# Altium schematic sheet 02_OCDS.SchDoc
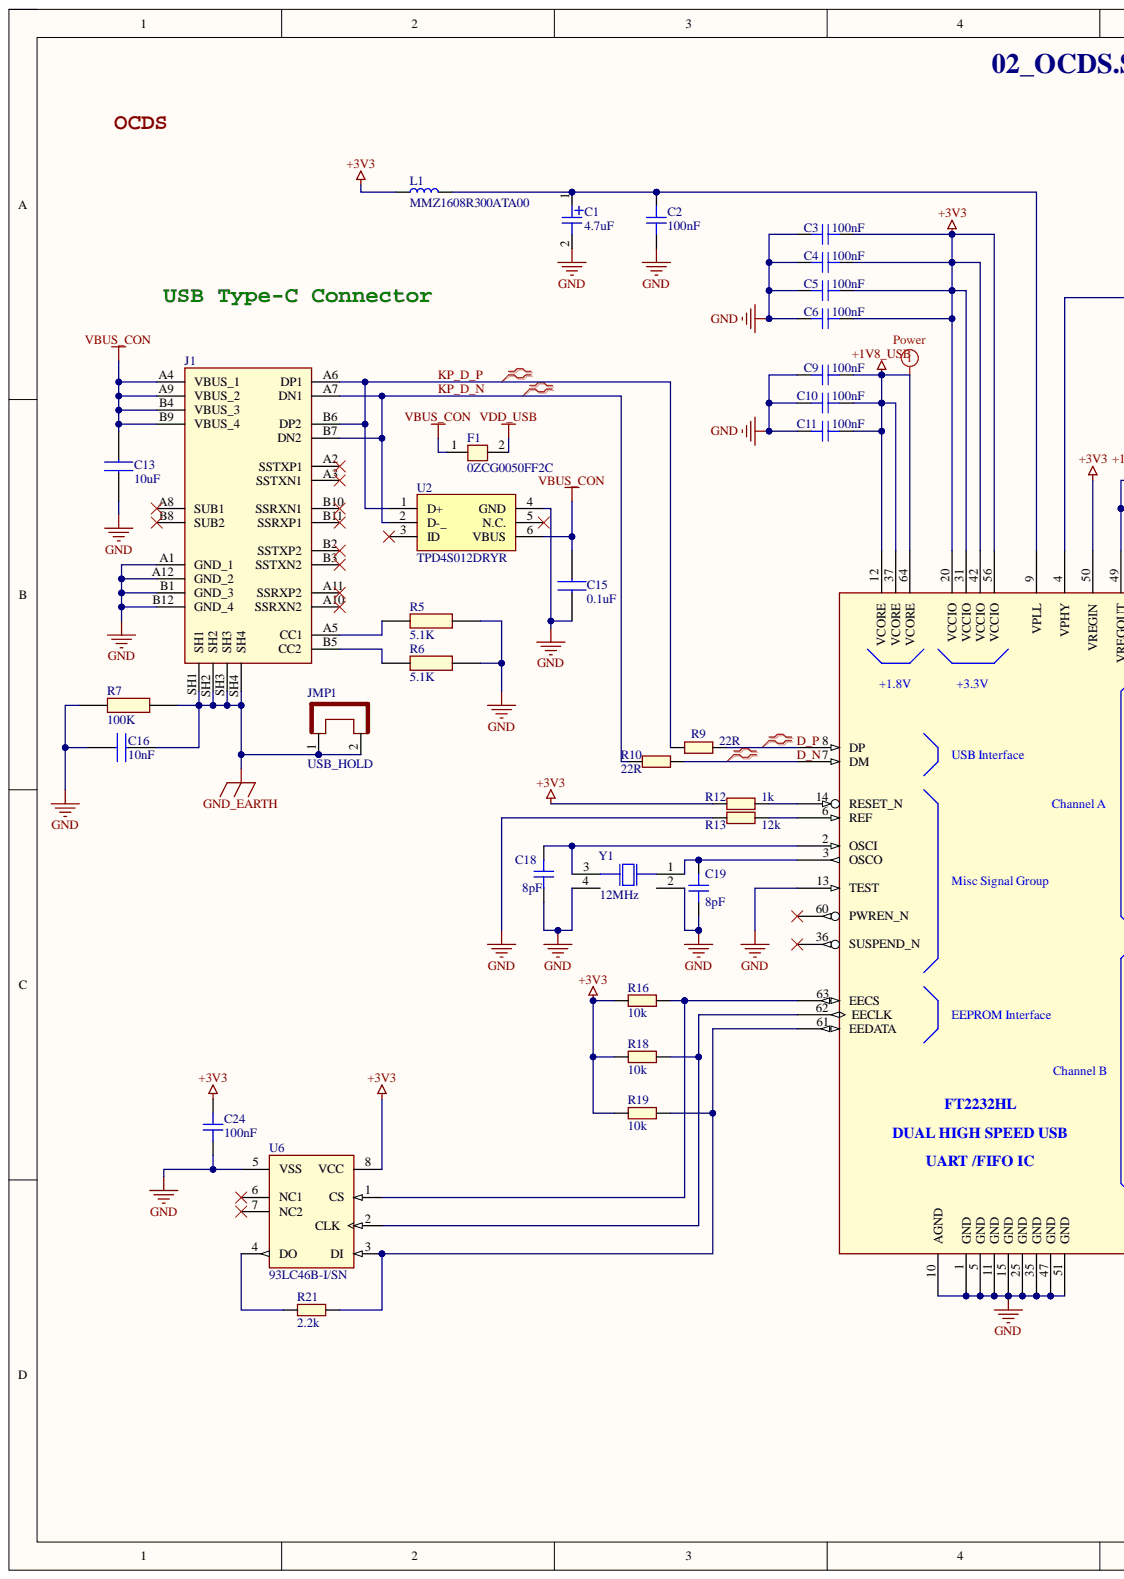
[diagram p0: 02_OCDS.SchDoc, left]
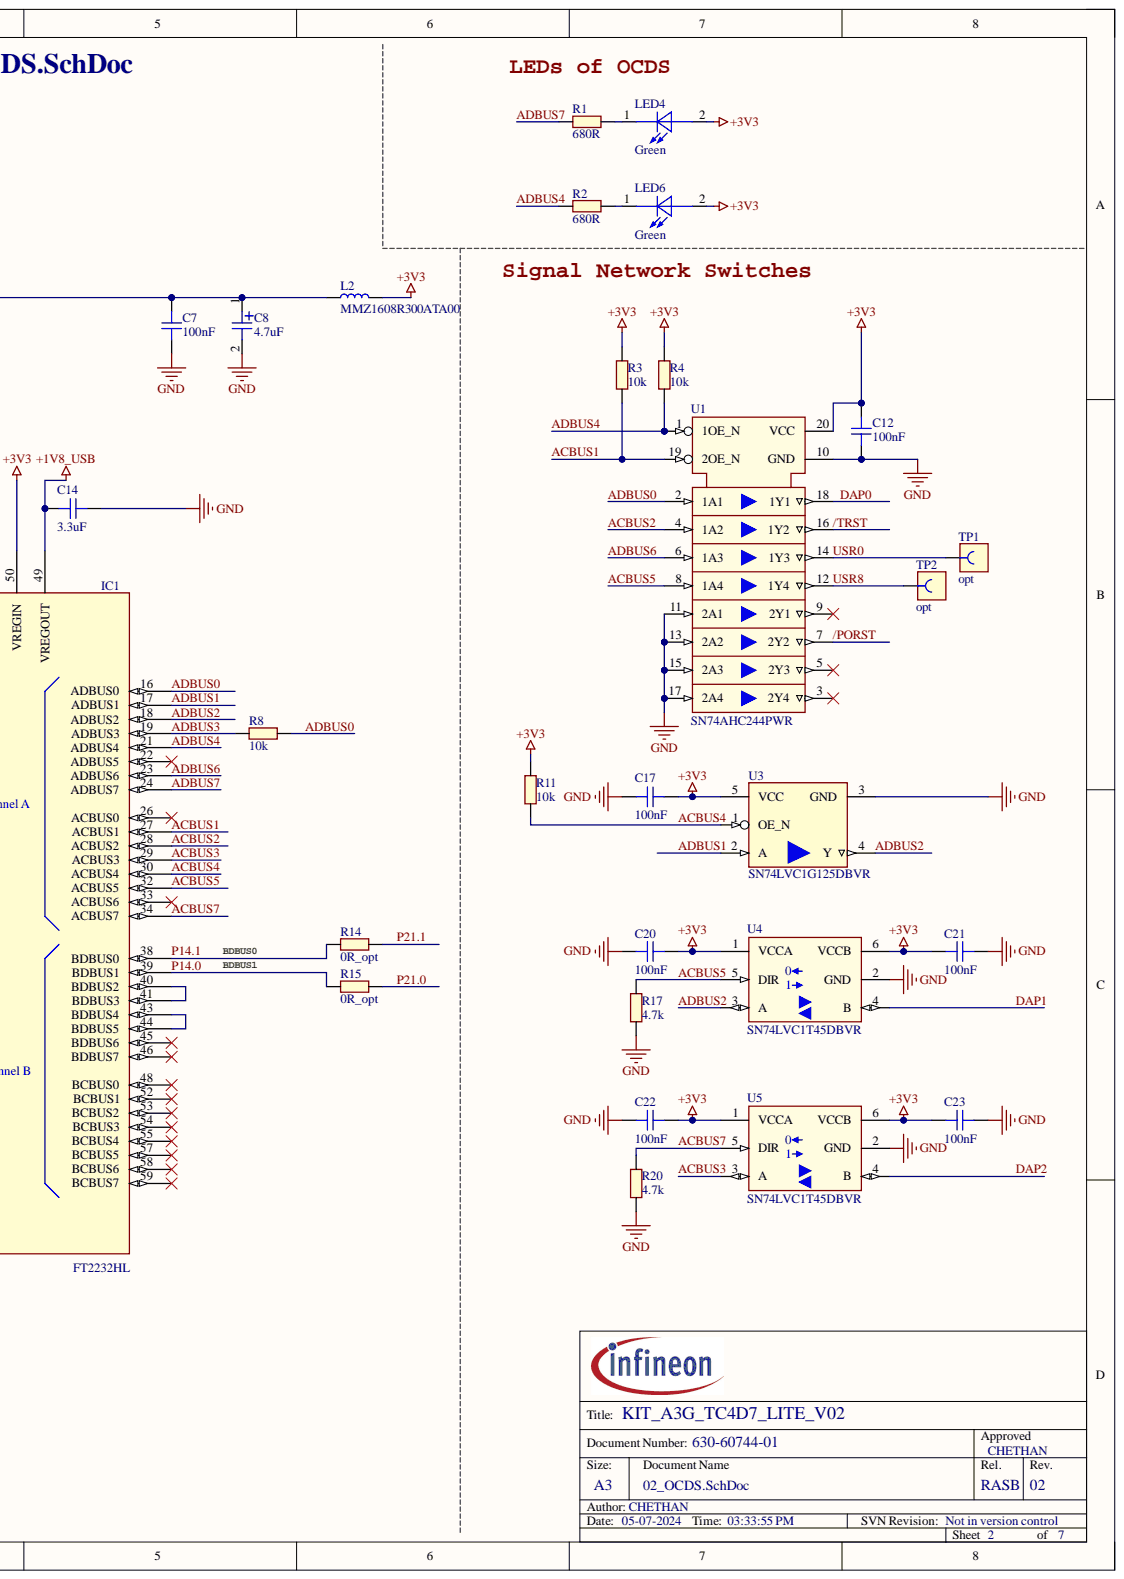
[diagram p1: 02_OCDS.SchDoc, right]

## Parts
C1  4.7uF  [CAPMP3216X180N]
C2  100nF  [CAPC1005X55N-1]
C3  100nF  [CAPC1005X55N-1]
C4  100nF  [CAPC1005X55N-1]
C5  100nF  [CAPC1005X55N-1]
C6  100nF  [CAPC1005X55N-1]
C7  100nF  [CAPC1005X55N-1]
C8  4.7uF  [CAPMP3216X180N]
C9  100nF  [CAPC1005X55N-1]
C10  100nF  [CAPC1005X55N-1]
C11  100nF  [CAPC1005X55N-1]
C12  100nF  [CAPC1005X55N-1]
C13  10uF  [CAPC2012X145N]
C14  3.3uF  [CAPC2013X95N]
C15  0.1uF  [CAPC1005X55N]
C16  10nF  [CAPC1005X55N-1]
C17  100nF  [CAPC1005X55N-1]
C18  8pF  [CAPC1005X55N-0]
C19  8pF  [CAPC1005X55N-0]
C20  100nF  [CAPC1005X55N-1]
C21  100nF  [CAPC1005X55N-1]
C22  100nF  [CAPC1005X55N-1]
C23  100nF  [CAPC1005X55N-1]
C24  100nF  [CAPC1005X55N-1]
F1  0ZCG0050FF2C  [0ZCG0050FF2C]
IC1  FT2232HL  [QFP50P1200X1200X160-64N]
J1  DX07S024XJ1R1100  [DX07S024XJ1R1100]  (value not drawn)
JMP1  USB_HOLD
L1  MMZ1608R300ATA00  [INDC1608X95N_MMZ1608]
L2  MMZ1608R300ATA00  [INDC1608X95N_MMZ1608]
LED4  Green  [LED-SMD-LG L29K-XXXX-24]
LED6  Green  [LED-SMD-LG L29K-XXXX-24]
R1  680R  [RESC1608X55N-1]
R2  680R  [RESC1608X55N-1]
R3  10k  [RESC1005X40N]
R4  10k  [RESC1005X40N]
R5  5.1K  [RESC1005X40N]
R6  5.1K  [RESC1005X40N]
R7  100K  [RESC1005X40N_1]
R8  10k  [RESC1005X40N]
R9  22R  [RESC1005X40N]
R10  22R  [RESC1005X40N]
R11  10k  [RESC1005X40N]
R12  1k  [RESC1005X40N-1]
R13  12k  [RESC1005X40N]
R14  0R_opt  [RESC1609X50N]
R15  0R_opt  [RESC1609X50N]
R16  10k  [RESC1005X40N]
R17  4.7k  [RESC1005X40N-1]
R18  10k  [RESC1005X40N]
R19  10k  [RESC1005X40N]
R20  4.7k  [RESC1005X40N-1]
R21  2.2k  [RESC1005X40N]
TP1  opt  [TPCW100D120H460B]
TP2  opt  [TPCW100D120H460B]
U1  SN74AHC244PWR  [SOP65P640X120-20N]
U2  TPD4S012DRYR  [TPD4S012DRYR]
U3  SN74LVC1G125DBVR  [SOT95P280X145-5N-2]
U4  SN74LVC1T45DBVR  [SOT95P280X145-6N-6]
U5  SN74LVC1T45DBVR  [SOT95P280X145-6N-6]
U6  93LC46B-I/SN  [SOIC127P600X175-8N-1]
Y1  12MHz  [XTAL-SMD-NX3225SA]

## Nets
+1V8_USB: C9.2 C10.2 C11.2 C14.2 IC1.12(VCORE) IC1.37(VCORE) IC1.49(VREGOUT) IC1.64(VCORE)
+3V3: C3.2 C4.2 C5.2 C6.2 C12.2 C17.2 C20.2 C21.2 C22.2 C23.2 C24.2 IC1.20(VCCIO) IC1.31(VCCIO) IC1.42(VCCIO) IC1.50(VREGIN) IC1.56(VCCIO) L1.1 L2.2 LED4.2 LED6.2 R3.2 R4.2 R11.2 R12.2 R16.2 R18.2 R19.2 U1.20(VCC) U3.5(VCC) U4.1(VCCA) U4.6(VCCB) U5.1(VCCA) U5.6(VCCB) U6.8(VCC)
GND: C1.2 C2.1 C3.1 C4.1 C5.1 C6.1 C7.1 C8.2 C9.1 C10.1 C11.1 C12.1 C13.1 C14.1 C15.1 C16.1 C17.1 C18.2 C19.2 C20.1 C21.1 C22.1 C23.1 C24.1 IC1.1(GND) IC1.5(GND) IC1.10(AGND) IC1.11(GND) IC1.13(TEST) IC1.15(GND) IC1.25(GND) IC1.35(GND) IC1.47(GND) IC1.51(GND) J1.A1(GND_1) J1.A12(GND_2) J1.B1(GND_3) J1.B12(GND_4) R5.2 R6.2 R7.1 R13.1 R17.1 R20.1 U1.10(GND) U1.11(2A1) U1.13(2A2) U1.15(2A3) U1.17(2A4) U2.4(GND) U3.3(GND) U4.2(GND) U5.2(GND) U6.5(VSS) Y1.2 Y1.4
GND_EARTH: C16.2 J1.SH1 J1.SH2 J1.SH3 J1.SH4 JMP1.1 JMP1.2 R7.2
VBUS_CON: C13.2 C15.2 F1.1 J1.A4(VBUS_1) J1.A9(VBUS_2) J1.B4(VBUS_3) J1.B9(VBUS_4) U2.6(VBUS)
VDD_USB: F1.2
/PORST: U1.7(2Y2)
/TRST: U1.16(1Y2)
ACBUS1: IC1.27(ACBUS1) R3.1 U1.19(2OE_N)
ACBUS2: IC1.28(ACBUS2) U1.4(1A2)
ACBUS3: IC1.29(ACBUS3) U5.3(A)
ACBUS4: IC1.30(ACBUS4) R11.1 U3.1(OE_N)
ACBUS5: IC1.32(ACBUS5) R17.2 U1.8(1A4) U4.5(DIR)
ACBUS7: IC1.34(ACBUS7) R20.2 U5.5(DIR)
ADBUS0: IC1.16(ADBUS0) R8.1 U1.2(1A1)
ADBUS1: IC1.17(ADBUS1) U3.2(A)
ADBUS2: IC1.18(ADBUS2) U3.4(Y) U4.3(A)
ADBUS3: IC1.19(ADBUS3) R8.2
ADBUS4: IC1.21(ADBUS4) R2.1 R4.1 U1.1(1OE_N)
ADBUS6: IC1.23(ADBUS6) U1.6(1A3)
ADBUS7: IC1.24(ADBUS7) R1.1
D_N: IC1.7(DM) R10.2
D_P: IC1.8(DP) R9.2
DAP0: U1.18(1Y1)
DAP1: U4.4(B)
DAP2: U5.4(B)
KP_D_N: J1.A7(DN1) J1.B7(DN2) R10.1 U2.2(D-_)
KP_D_P: J1.A6(DP1) J1.B6(DP2) R9.1 U2.1(D+)
P14.0: IC1.39(BDBUS1) R15.1
P14.1: IC1.38(BDBUS0) R14.1
P21.0: R15.2
P21.1: R14.2
USR0: TP1.1 U1.14(1Y3)
USR8: TP2.1 U1.12(1Y4)
NetC1_1: C1.1 C2.2 IC1.9(VPLL) L1.2
NetC7_2: C7.2 C8.1 IC1.4(VPHY) L2.1
NetC18_1: C18.1 IC1.2(OSCI) Y1.3
NetC19_1: C19.1 IC1.3(OSCO) Y1.1
NetIC1_6: IC1.6(REF) R13.2
NetIC1_14: IC1.14(RESET_N) R12.1
NetIC1_40: IC1.40(BDBUS2) IC1.41(BDBUS3)
NetIC1_43: IC1.43(BDBUS4) IC1.44(BDBUS5)
NetIC1_61: IC1.61(EEDATA) R19.1 R21.2 U6.3(DI)
NetIC1_62: IC1.62(EECLK) R18.1 U6.2(CLK)
NetIC1_63: IC1.63(EECS) R16.1 U6.1(CS)
NetJ1_A5: J1.A5(CC1) R5.1
NetJ1_B5: J1.B5(CC2) R6.1
NetLED4_1: LED4.1 R1.2
NetLED6_1: LED6.1 R2.2
NetR21_1: R21.1 U6.4(DO)

Not connected: IC1 22(ADBUS5) 26(ACBUS0) 33(ACBUS6) 36(SUSPEND_N) 45(BDBUS6) 46(BDBUS7) 48(BCBUS0) 52(BCBUS1) 53(BCBUS2) 54(BCBUS3) 55(BCBUS4) 57(BCBUS5) 58(BCBUS6) 59(BCBUS7) 60(PWREN_N); J1 A2(SSTXP1) A3(SSTXN1) A8(SUB1) A10(SSRXN2) A11(SSRXP2) B2(SSTXP2) B3(SSTXN2) B8(SUB2) B10(SSRXN1) B11(SSRXP1); U1 3(2Y4) 5(2Y3) 9(2Y1); U2 3(ID) 5(N.C.); U6 6(NC1) 7(NC2)

## Library data of the parts
C1 C8 = 307693: 4.7 µF Molded Tantalum Capacitors 10 V 1206 (3216 Metric) 5Ohm; Manufacturer: Kemet; Manufacturer Component Status: Active; Manufacturer Order Number: T491A475K010AT; Alternate View: Yes; Automotive Qualified: No; Combined Parameters: TC:Tantalumelectrolytic;Tol.:-10%/+10%;Vdc:10V; Legacy DeviceID: CAP01010557; QuickPick: 4.7uF / 10V / 10% / Tantalumelectrolytic / CAPMP3216X180N; Style: Single; Symbol Type: Capacitor; Single; Polarized; 2 Pins; Pin 1 Positive; Tolerance Neg: 10%; Type: Standard; Version Number: V01; Voltage DC (max): 10V; Article Number: 307693; Component Kind: Standard; ComponentLink1Description: Vendor WebSite; ComponentLink1URL: http://www.kemet.com; ComponentLink2Description: Datasheet; ComponentLink2URL: http://webnetprod.muc.infineon.com/PCBPortal_Prod/WorkFlows/AltiumComponent/StandardDocumentation?Versionid=307694; Legacy PartNumber: CAP01010557; Multible Parts: No; Package Description: Capacitor,polarized,Molded Body;3.20mm L X 1.60mm W X 1.80mm H; PackageReference: CAPMP3216X180N; Part Type: Capacitor; PLMStatus: Active; ROHS Compliant: Y; Second Source allowed: Yes; Supplier 1: Farnell; Supplier 2: DigiKey; Supplier 3: Mouser; Supplier Part Number 1: 1457427; Supplier Part Number 2: 399-3696-1-ND; Supplier Part Number 3: 80-T491A475K010; Technology: Electrolytic; Temperature max: 125; Temperature min: -55; Temperature Range: Military; Tolerance Pos: 10%; Value: 4.7uF; Library Name: AURIX_Lite_Kit_V2.0.SCHLIB
C2-C7 C9-C12 C17 C20-C24 = 308680: 0.1 µF ±10% 16V Ceramic Capacitor X7R 0402 (1005 Metric); Manufacturer: TDK Corporation; Manufacturer Component Status: Active; Manufacturer Order Number: CGA2B1X7R1C104K050BC; Multiple Parts: No; Alternate View: Yes; Automotive Qualified: AEC Q200; Combined Parameters: TC:X7R (EIA);Tol.:-10%/+10%;Vdc:16V; Legacy DeviceID: CAP01010669; Package Imperial: 0402; Package Metric: 1005; QuickPick: 100nF / 16V / 10% / X7R (EIA) / 0402 (1005); Style: Single; Symbol Type: Capacitor; Single; Standard; 2 Pins; Type: Standard; Version Number: V01; Article Number: 308680; Component Kind: Standard; ComponentLink1Description: Vendor WebSite; ComponentLink1URL: http://www.tdk.com; ComponentLink2Description: Datasheet; ComponentLink2URL: http://webnetprod.muc.infineon.com/PCBPortal_Prod/WorkFlows/AltiumComponent/StandardDocumentation?Versionid=308681; Legacy PartNumber: CAP01010669; Package Description: Capacitor,non-polarized,Chip;1.00mm L X 0.50mm W X 0.55mm H; PackageReference: 0402 (1005); Part Type: Capacitor; PLMStatus: Active; ROHS Compliant: Y; Second Source allowed: Yes; Supplier 1: Farnell; Supplier 2: DigiKey; Supplier 3: Mouser; Supplier Part Number 1: 2458205; Supplier Part Number 2: 445-5613-1-ND; Supplier Part Number 3: 810-CGA2B1X7R1C104K; Technology: Ceramic; Temperature max: 125; Temperature min: -55; Temperature Range: Military; Tolerance Neg: 10%; Tolerance Pos: 10%; Value: 100nF; Voltage DC (max): 16V; Library Name: AURIX_Lite_Kit_V2.0.SCHLIB
C13 = C2012X5R1E106K085AC: 10 µF ±10% 25V Ceramic Capacitor X5R 0805 (2012 Metric); Manufacturer: TDK; Geometry.Height: 0.75mm; Arrow Part Number: C2012X5R1E106K085AC; Arrow Price/Stock: https://www.arrow.com/en/products/c2012x5r1e106k085ac/tdk; Component Kind: Standard; Datasheet Link: https://product.tdk.com/system/files/dam/doc/product/capacitor/ceramic/mlcc/catalog/mlcc_commercial_general_en.pdf; Library Name: C2012X5R1E106K085AC.SchLib; Manufacturer Order Number: C2012X5R1E106K085AC; Manufacturer_Name: TDK; Manufacturer_Part_Number: C2012X5R1E106K085AC; Mouser Part Number: 810-C2012X5R1E106KAC; Mouser Price/Stock: https://www.mouser.co.uk/ProductDetail/TDK/C2012X5R1E106K085AC?qs=6JAMGB%252BEdkxKBx%252Bl%252BExtPw%3D%3D; Supplier Part Number 2: 445-14387-1-ND; Value: 10uF
C14 = 277032: 3.3 µF ±10% 10V Ceramic Capacitor X5R 0805 (2012 Metric); Manufacturer: MuRata; Manufacturer Component Status: Active; Manufacturer Order Number: GRM219R61A335KE19D; Alternate View: Yes; Automotive Qualified: No; Combined Parameters: TC:X5R (EIA);Tol.:-10%/+10%;Vdc:10V; Legacy DeviceID: CAP01004421; Package Imperial: 0805; Package Metric: 2012; QuickPick: 3.3uF / 10V / 10% / X5R (EIA) / CAPC2013X95N; Style: Single; Symbol Type: Capacitor; Single; Standard; 2 Pins; Type: Standard; Version Number: V01; Application: Standard; Article Number: 277032; Component Kind: Standard; ComponentLink1Description: Vendor WebSite; ComponentLink1URL: http://www.murata.com/; ComponentLink2Description: Datasheet; ComponentLink2URL: http://webnetprod.muc.infineon.com/PCBPortal_Prod/WorkFlows/AltiumComponent/StandardDocumentation?Versionid=277033; Legacy PartNumber: CAP01004421; Multiple Parts: No; Package Description: Capacitor,non-polarized,Chip;2.00mm L X 1.25mm W X 0.95mm H; PackageReference: CAPC2013X95N; Part Type: Capacitor; PLMStatus: Active; ROHS Compliant: Y; Second Source allowed: Yes; Supplier 1: Farnell; Supplier 2: DigiKey; Supplier 3: Mouser; Supplier Part Number 2: 490-1706-1-ND; Technology: Ceramic; Temperature max: 85; Temperature min: -55; Temperature Range: Military; Tolerance Neg: 10%; Tolerance Pos: 10%; Value: 3.3uF; Voltage DC (max): 10V; Library Name: AURIX_Lite_Kit_V2.0.SCHLIB
C15 = CL05F104ZO5NNNC: 0.1 µF -20%, +80% 16V Ceramic Capacitor Y5V (F) 0402 (1005 Metric); Manufacturer: Samsung Electro-Mechanics; Manufacturer_Name: SAMSUNG; Geometry.Height: 0.55mm; Arrow Part Number: CL05F104ZO5NNNC; Arrow Price/Stock: https://www.arrow.com/en/products/cl05f104zo5nnnc/samsung-electro-mechanics; Component Kind: Standard; Datasheet Link: https://datasheet.datasheetarchive.com/originals/distributors/Datasheets-DGA10/2407946.pdf; Library Name: CL05F104ZO5NNNC.SchLib; Manufacturer Order Number: CL05F104ZO5NNNC; Manufacturer_Part_Number: CL05F104ZO5NNNC; Mouser Part Number: 187-CL05F104ZO5NNNC; Mouser Price/Stock: https://www.mouser.co.uk/ProductDetail/Samsung-Electro-Mechanics/CL05F104ZO5NNNC?qs=349EhDEZ59pd3Zv0yIJicw%3D%3D; Supplier Part Number 2: 1276-1004-1-ND; Value: 0.1UF
C16 = GRM155R71H103JA88D: 10000 pF ±5% 50V Ceramic Capacitor X7R 0402 (1005 Metric); Manufacturer: Murata Electronics; Geometry.Height: 0.55mm; Arrow Part Number: GRM155R71H103JA88D; Arrow Price/Stock: https://www.arrow.com/en/products/grm155r71h103ja88d/murata-manufacturing; Component Kind: Standard; Datasheet Link: https://datasheet.datasheetarchive.com/originals/distributors/Datasheets_SAMA/ec4f1577dddcc7a13ddad897a28002d3.pdf; Library Name: GRM155R71H103JA88D.SchLib; Manufacturer Order Number: GRM155R71H103JA88D; Manufacturer_Name: Murata Electronics; Manufacturer_Part_Number: GRM155R71H103JA88D; Mouser Part Number: 81-GRM155R71H103JA8D; Mouser Price/Stock: https://www.mouser.co.uk/ProductDetail/Murata-Electronics/GRM155R71H103JA88D?qs=18WYLvqSxEpQeSHF5ZnUyQ%3D%3D; Supplier Part Number 2: 490-7763-1-ND; Supplier Part Number 3: 81-GRM155R71H103JA8D; Value: 10000 pF
C18 C19 = 288376: 8 pF ±0.05pF 50V Ceramic Capacitor C0G, NP0 0402 (1005 Metric); Manufacturer: MuRata; Manufacturer Component Status: Active; Manufacturer Order Number: GJM1555C1H8R0WB01D; Multiple Parts: No; Alternate View: Yes; Automotive Qualified: AEC Q200; Combined Parameters: TC:C0G (EIA) / NP0;Tol.:-5fF/+5fF;Vdc:50V; Legacy DeviceID: CAP01006748; Package Imperial: 0402; Package Metric: 1005; QuickPick: 8pF / 50V / 5fF / C0G (EIA) / NP0 / CAPC1005X55N-0; Style: Single; Symbol Type: Capacitor; Single; Standard; 2 Pins; Tolerance Neg: 5fF; Type: Standard; Version Number: V01; Application: Standard; Article Number: 288376; Component Kind: Standard; ComponentLink1Description: Vendor WebSite; ComponentLink1URL: http://www.murata.com/; ComponentLink2Description: Datasheet; ComponentLink2URL: http://webnetprod.muc.infineon.com/PCBPortal_Prod/WorkFlows/AltiumComponent/StandardDocumentation?Versionid=288377; Legacy PartNumber: CAP01006748; Package Description: Capacitor,non-polarized,Chip;1.00mm L X 0.50mm W X 0.55mm H; PackageReference: CAPC1005X55N-0; Part Type: Capacitor; PLMStatus: Active; ROHS Compliant: Y; Second Source allowed: Yes; Supplier 1: Farnell; Supplier 2: DigiKey; Supplier 3: Mouser; Supplier Part Number 2: 490-11239-1-ND; Supplier Part Number 3: 81-GJM1555C1H8R0WB1D; Technology: Ceramic; Temperature max: 125; Temperature min: -55; Temperature Range: Military; Tolerance Pos: 5fF; Value: 8pF; Voltage DC (max): 50V; Library Name: AURIX_Lite_Kit_V2.0.SCHLIB
F1 = 0ZCG0050FF2C: Polymeric PTC Resettable Fuse 16V 500 mA Ih Surface Mount 1812 (4532 Metric), Concave; Manufacturer: Bel Fuse Inc.; Component Kind: Standard; Library Name: 0ZCG0050FF2C.SchLib; Manufacturer Order Number: 0ZCG0050FF2C; Supplier Part Number 2: 507-1761-1-ND; Value: 0ZCG0050FF2C
IC1 = 403172: IC USB HS DUAL UART/FIFO 64-LQFP; Legacy PartNumber: IC02600036; Manufacturer: Future Technology Devices International; Manufacturer Component Status: Active; Manufacturer Order Number: FT2232HL-REEL; Automotive Qualified: No; Combined Parameters: Standard Interface Interface/Transceiver;Vdc min/max:3V/3.6V;Iout:16mA;Fmax:12MHz; Legacy DeviceID: FTDI_FT2232HL; QuickPick: LQFP-64; Second Source allowed: Yes; Version Number: V01; Voltage DC (max): na; Application: Standard Interface; Article Number: 403172; Component Kind: Standard; ComponentLink1Description: Vendor WebSite; ComponentLink1URL: http://www.ftdichip.com/; ComponentLink2Description: Datasheet; ComponentLink2URL: http://webnetprod.muc.infineon.com/PCBPortal_Prod/WorkFlows/AltiumComponent/StandardDocumentation?Versionid=403173; Package Description: QFP,0.50mm pitch,square;64 pin,10.00mm L X 10.00mm W X 1.60mm H body; PackageReference: LQFP-64; Part Type: Integrated Circuit; PLMStatus: Active; ROHS Compliant: Y; Supplier 1: Farnell; Supplier 2: DigiKey; Supplier 3: Mouser; Supplier Part Number 2: 768-1024-1-ND; Supplier Part Number 3: 895-FT2232HL; Temperature max: 85; Temperature min: -40; Temperature Range: Industrial; Type: Interface/Transceiver; Value: FT2232HL; Library Name: AURIX_Lite_Kit_V2.0.SCHLIB
J1 = DX07S024XJ1R1100: USB-C (USB TYPE-C) USB 3.2 Gen 2 (USB 3.1 Gen 2, Superspeed + (USB 3.1)) Receptacle Connector 24 Position Surface Mount, Right Angle; Through Hole; Manufacturer: JAE Electronics; Manufacturer_Name: JAPAN AVIATION ELECTRONICS INDUSTRY; Geometry.Height: 3.44mm; Component Kind: Standard; Datasheet Link: https://www.jae.com/direct/topics/topics_file_download/topics_id=66515&ext_no=06&index=0&_lang=en&v=2021012116273642441909; Library Name: DX07S024XJ1R1100.SchLib; Manufacturer Order Number: DX07S024XJ1R1100; Manufacturer_Part_Number: DX07S024XJ1R1100; Supplier Part Number 2: 670-2848-1-ND
L1 L2 = 326212: 30 Ohms @ 100 MHz 1 Signal Line Ferrite Bead 0603 (1608 Metric) 1.5A 50mOhm; Manufacturer: TDK Corporation; Manufacturer Component Status: Active; Alternate View: Yes; Automotive Qualified: No; Combined Parameters: Tol.:-25%/+25%;Rdc:-;Idc:1.5A;Fres:-; Legacy DeviceID: IND00702701; Package Imperial: 0603; Package Metric: 1608; Symbol Type: Inductor; Single; Standard; 2 Pins; Tolerance Neg: 25%; Value: 30R; Version Number: V01; Voltage DC (max): na; Article Number: 326212; Component Kind: Standard; ComponentLink1Description: Vendor WebSite; ComponentLink1URL: http://www.tdk.com; ComponentLink2Description: Datasheet; ComponentLink2URL: http://webnetprod.muc.infineon.com/PCBPortal_Prod/WorkFlows/AltiumComponent/StandardDocumentation?Versionid=326213; Legacy PartNumber: IND00702701; Manufacturer Order Number: MMZ1608R300ATA00; Multiple Parts: No; Package Description: Inductor,Chip;1.60mm L X 0.80mm W X 0.95mm H; PackageReference: 1608; Part Type: Inductor; PLMStatus: Active; QuickPick: 1608; Second Source allowed: Yes; Supplier 1: Farnell; Supplier 2: DigiKey; Supplier 3: Mouser; Supplier Part Number 1: 2137003; Supplier Part Number 2: 445-MMZ1608R300ATA00CT-ND; Supplier Part Number 3: 810-MMZ1608R300ATA00; Temperature Range: Military; Tolerance Pos: 25%; Library Name: AURIX_Lite_Kit_V2.0.SCHLIB
LED4 LED6 = 395008: Green 570nm LED Indication - Discrete 1.7V 0603 (1608 Metric); Manufacturer: OSRAM Opto Semiconductors; Manufacturer Component Status: Active; Manufacturer Order Number: LG L29K-G2J1-24; Automotive Qualified: No; Combined Parameters: Vf:2.2V;If:20mA;Ur:12V;Ir:10nA;Pmax:-; Legacy DeviceID: DIO01300294; QuickPick: LED / 0603; Second Source allowed: Yes; Style: Single; Symbol Type: Standard LED Symbol, 1 Cathode, 2 Anode; Version Number: V01; Voltage DC (max): na; Application: Standard; Article Number: 395008; Component Kind: Standard; ComponentLink1Description: Vendor WebSite; ComponentLink1URL: http://www.osram-os.com; ComponentLink2Description: Datasheet; ComponentLink2URL: http://webnetprod.muc.infineon.com/PCBPortal_Prod/WorkFlows/AltiumComponent/StandardDocumentation?Versionid=395009; Legacy PartNumber: DIO01300294; Package Description: LED;2 pin,1.3mm W X 0.80mm L X 0.67mm H body; PackageReference: 0603; Part Type: Diode; PLMStatus: Active; ROHS Compliant: Y; Supplier 1: Farnell; Supplier 2: DigiKey; Supplier 3: Mouser; Supplier Part Number 2: 475-2709-1-ND; Supplier Part Number 3: 720-LGL29KG2J124Z; Temperature max: 100; Temperature min: -40; Temperature Range: Industrial; Type: LED; Value: Green; Library Name: AURIX_Lite_Kit_V2.0.SCHLIB
R1 R2 = 327766_NEW: 680 Ohms ±1% 0.1W, 1/10W Chip Resistor 0603 (1608 Metric) Moisture Resistant Thick Film; Manufacturer: Yageo; Manufacturer Component Status: Active; Manufacturer Order Number: RC0603FR-07680RL; Alternate View: Yes; Article Number: 327766; Automotive Qualified: No; Combined Parameters: TCR:100ppm/K;Tol.:-1%/+1%;Vdc:75V;Idc:-;P:100mW; Legacy DeviceID: RES00000077; QuickPick: 680R / 1% / 0.10 / 0603; Style: Single; Symbol Type: Resistor; Single; Standard; 2 Pins; Version Number: V01; Voltage DC (max): 75V; Component Kind: Standard; ComponentLink1Description: Vendor WebSite; ComponentLink1URL: http://www.yageo.com/; ComponentLink2Description: Datasheet; ComponentLink2URL: http://webnetprod.muc.infineon.com/PCBPortal_Prod/WorkFlows/AltiumComponent/StandardDocumentation?Versionid=327767; Legacy PartNumber: RES00000077; Multiple Parts: No; PackageReference: 0603; Part Type: Resistor; PLMStatus: Active; ROHS Compliant: Y; Second Source allowed: Yes; Supplier 1: Farnell; Supplier 2: DigiKey; Supplier 3: Mouser; Supplier Part Number 1: 9238468; Supplier Part Number 2: 311-680HRCT-ND; Supplier Part Number 3: 603-RC0603FR-07680RL; Technology: Thick Film; Temperature max: 155; Temperature min: -55; Temperature Range: Military; Tolerance Neg: 1%; Tolerance Pos: 1%; Type: Standard; Value: 680R; Library Name: AURIX_Lite_Kit_V2.0.SCHLIB
R3 R4 R8 R11 R16 R18 R19 = 342167: 10 kOhms ±1% 0.063W, 1/16W Chip Resistor 0402 (1005 Metric) Automotive AEC-Q200 Thick Film; Manufacturer: Vishay; Manufacturer Component Status: Active; Manufacturer Order Number: CRCW040210K0FKED; Multiple Parts: No; Alternate View: Yes; Automotive Qualified: AEC Q200; Combined Parameters: TCR:100ppm/K;Tol.:-1%/+1%;Vdc:50V;Idc:-;P:63mW; Legacy DeviceID: RES01800457; QuickPick: 10k / 1% / 0.0630 / 0402; Style: Single; Symbol Type: Resistor; Single; Standard; 2 Pins; Version Number: V01; Voltage DC (max): 50V; Article Number: 342167; Component Kind: Standard; ComponentLink1Description: Vendor WebSite; ComponentLink1URL: http://www.vishay.com; ComponentLink2Description: Datasheet; ComponentLink2URL: http://webnetprod.muc.infineon.com/PCBPortal_Prod/WorkFlows/AltiumComponent/StandardDocumentation?Versionid=342168; Legacy PartNumber: RES01800457; PackageReference: 0402; Part Type: Resistor; PLMStatus: Active; ROHS Compliant: Y; Second Source allowed: Yes; Supplier 1: Farnell; Supplier 2: DigiKey; Supplier 3: Mouser; Supplier Part Number 2: 541-10.0KLCT-ND; Supplier Part Number 3: 71-CRCW0402-10K-E3; Technology: Thick Film; Temperature max: 155; Temperature min: -55; Temperature Range: Military; Tolerance Neg: 1%; Tolerance Pos: 1%; Type: Standard; Value: 10k; Library Name: AURIX_Lite_Kit_V2.0.SCHLIB
R5 R6 = RC0402JR-075K1L: 5.1 kOhms ±5% 0.063W, 1/16W Chip Resistor 0402 (1005 Metric) Moisture Resistant Thick Film; Manufacturer: YAGEO; Manufacturer_Name: KEMET; Geometry.Height: 0.4mm; Arrow Part Number: RC0402JR-075K1L; Arrow Price/Stock: https://www.arrow.com/en/products/rc0402jr-075k1l/yageo; Component Kind: Standard; Datasheet Link: https://datasheet.datasheetarchive.com/originals/distributors/Datasheets_SAMA/902f9e387b938f871d31120f5fc1d65e.pdf; Library Name: RC0402JR-075K1L.SchLib; Manufacturer Order Number: RC0402JR-075K1L; Manufacturer_Part_Number: RC0402JR-075K1L; Mouser Part Number: 603-RC0402JR-075K1L; Mouser Price/Stock: https://www.mouser.co.uk/ProductDetail/Yageo/RC0402JR-075K1L?qs=uM1JSSdPjOAGd%2F8wBzNU8g%3D%3D; Supplier Part Number 2: 311-5.1KJRCT-ND; Supplier Part Number 3: 603-RC0402JR-075K1L; Value: 5.1K
R7 = ERJ-2GEJ104X: 100 kOhms ±5% 0.1W, 1/10W Chip Resistor 0402 (1005 Metric) Automotive AEC-Q200 Thick Film; Manufacturer: Panasonic Electronic Components; Manufacturer_Name: Panasonic; Arrow Part Number: ERJ-2GEJ104X; Arrow Price/Stock: https://www.arrow.com/en/products/erj-2gej104x/panasonic?region=nac; Component Kind: Standard; Library Name: ERJ-2GEJ104X.SchLib; Manufacturer Order Number: ERJ-2GEJ104X; Manufacturer_Part_Number: ERJ-2GEJ104X; Mouser Part Number: 667-ERJ-2GEJ104X; Mouser Price/Stock: https://www.mouser.co.uk/ProductDetail/Panasonic/ERJ-2GEJ104X?qs=6%252BUraArZVSCJP%2FfQqKULtw%3D%3D; Supplier Part Number 2: P100KJCT-ND; Supplier Part Number 3: 667-ERJ-2GEJ104X; Value: 100K
R9 R10 = 340637: 22 Ohms ±1% 0.063W, 1/16W Chip Resistor 0402 (1005 Metric) Automotive AEC-Q200 Thick Film; Manufacturer: Vishay; Manufacturer Component Status: Active; Manufacturer Order Number: CRCW040222R0FKED; Multiple Parts: No; Alternate View: Yes; Automotive Qualified: AEC Q200; Combined Parameters: TCR:100ppm/K;Tol.:-1%/+1%;Vdc:50V;Idc:-;P:63mW; Legacy DeviceID: RES01800151; Style: Single; Symbol Type: Resistor; Single; Standard; 2 Pins; Version Number: V01; Voltage DC (max): 50V; Article Number: 340637; Component Kind: Standard; ComponentLink1Description: Vendor WebSite; ComponentLink1URL: http://www.vishay.com; ComponentLink2Description: Datasheet; ComponentLink2URL: http://webnetprod.muc.infineon.com/pcbportal_prod/WorkFlows/AltiumComponent/StandardDocumentation?Versionid=340638; Legacy PartNumber: RES01800151; PackageReference: 0402; Part Type: Resistor; PLMStatus: Active; RoHS Compliant: Y; Second Source allowed: Yes; Supplier 1: Farnell; Supplier 2: DigiKey; Supplier 3: Mouser; Supplier Part Number 2: 541-22.0LCT-ND; Supplier Part Number 3: 71-CRCW0402-22-E3; Technology: Thick Film; Temperature max: 155; Temperature min: -55; Temperature Range: Military; Tolerance Neg: 1%; Tolerance Pos: 1%; Type: Standard; Value: 22R; Library Name: AURIX_Lite_Kit_V2.0.SCHLIB
R12 = 370987: 1 kOhms ±5% 0.063W, 1/16W Chip Resistor 0402 (1005 Metric) Moisture Resistant Thick Film; Manufacturer: Yageo; Manufacturer Component Status: Active; Manufacturer Order Number: RC0402JR-131KL; Alternate View: Yes; Automotive Qualified: No; Combined Parameters: TCR:100ppm/K;Tol.:-5%/+5%;Vdc:50V;Idc:-;P:62.5mW; Legacy DeviceID: RES01806043; QuickPick: 1k / 5% / 0.0625 / 0402; Style: Single; Symbol Type: Resistor; Single; Standard; 2 Pins; Version Number: V01; Voltage DC (max): 50V; Article Number: 370987; Component Kind: Standard; ComponentLink1Description: Vendor WebSite; ComponentLink1URL: http://www.yageo.com/; ComponentLink2Description: Datasheet; ComponentLink2URL: http://webnetprod.muc.infineon.com/PCBPortal_Prod/WorkFlows/AltiumComponent/StandardDocumentation?Versionid=370988; Legacy PartNumber: RES01806043; Multiple Parts: No; PackageReference: 0402; Part Type: Resistor; PLMStatus: Active; ROHS Compliant: Y; Second Source allowed: Yes; Supplier 1: Farnell; Supplier 2: DigiKey; Supplier 3: Mouser; Supplier Part Number 1: 1799315; Supplier Part Number 2: 13-RC0402JR-131KLCT-ND; Supplier Part Number 3: 603-RC0402JR-131KL; Technology: Thick Film; Temperature max: 155; Temperature min: -55; Temperature Range: Military; Tolerance Neg: 5%; Tolerance Pos: 5%; Type: Standard; Value: 1k; Library Name: AURIX_Lite_Kit_V2.0.SCHLIB
R13 = 342207: 12 kOhms ±1% 0.2W, 1/5W Chip Resistor 0402 (1005 Metric) Automotive AEC-Q200, Pulse Withstanding Thick Film; Manufacturer: Vishay; Manufacturer Component Status: Active; Manufacturer Order Number: CRCW040212K0FKEDHP; Multiple Parts: No; Alternate View: Yes; Automotive Qualified: AEC Q200; Combined Parameters: TCR:100ppm/K;Tol.:-1%/+1%;Vdc:50V;Idc:-;P:63mW; Legacy DeviceID: RES01800465; QuickPick: 12k / 1% / 0.0630 / 0402; Style: Single; Symbol Type: Resistor; Single; Standard; 2 Pins; Version Number: V01; Voltage DC (max): 50V; Article Number: 342207; Component Kind: Standard; ComponentLink1Description: Vendor WebSite; ComponentLink1URL: http://www.vishay.com; ComponentLink2Description: Datasheet; ComponentLink2URL: http://webnetprod.muc.infineon.com/PCBPortal_Prod/WorkFlows/AltiumComponent/StandardDocumentation?Versionid=342208; Legacy PartNumber: RES01800465; PackageReference: 0402; Part Type: Resistor; PLMStatus: Active; ROHS Compliant: Y; Second Source allowed: Yes; Supplier 1: Farnell; Supplier 2: DigiKey; Supplier 3: Mouser; Supplier Part Number 2: 541-CRCW040212K0FKEDHPCT-ND; Supplier Part Number 3: 71-CRCW040212K0FKEDH; Technology: Thick Film; Temperature max: 155; Temperature min: -55; Temperature Range: Military; Tolerance Neg: 1%; Tolerance Pos: 1%; Type: Standard; Value: 12k; Library Name: AURIX_Lite_Kit_V2.0.SCHLIB
R14 R15 = 343882: 0 Ohms Jumper Chip Resistor 0603 (1608 Metric) Automotive AEC-Q200, Pulse Withstanding Thick Film; Manufacturer: Vishay; Manufacturer Order Number: CRCW06030000Z0EAHP; Multiple Parts: No; Alternate View: Yes; Automotive Qualified: AEC Q200; Combined Parameters: TCR:-;Tol.:-0R/+0R;Vdc:75V;Idc:2A;P:-; Legacy DeviceID: RES01800800; PLMStatus: Active; QuickPick: 0R / 0R / 0603; Style: Single; Symbol Type: Resistor; Single; Standard; 2 Pins; Tolerance Neg: 0R; Value: 0R; Version Number: V01; Voltage DC (max): 75V; Article Number: 343882; Component Kind: Standard; ComponentLink1Description: Vendor WebSite; ComponentLink1URL: http://www.vishay.com; ComponentLink2Description: Datasheet; ComponentLink2URL: http://webnetprod.muc.infineon.com/PCBPortal_Prod/WorkFlows/AltiumComponent/StandardDocumentation?Versionid=343883; Legacy PartNumber: RES01800800; PackageReference: 0603; Part Type: Resistor; ROHS Compliant: Y; Second Source allowed: Yes; Supplier 1: Farnell; Supplier 2: DigiKey; Supplier 3: Mouser; Supplier Part Number 2: 541-0.0SBCT-ND; Supplier Part Number 3: 71-CRCW06030000Z0EAH; Technology: Thick Film; Temperature max: 155; Temperature min: -55; Temperature Range: Military; Tolerance Pos: 0R; Type: Standard; Library Name: AURIX_Lite_Kit_V2.0.SCHLIB
R17 R20 = 327541: 4.7 kOhms ±1% 0.063W, 1/16W Chip Resistor 0402 (1005 Metric) Moisture Resistant Thick Film; Manufacturer: Yageo; Manufacturer Component Status: Active; Manufacturer Order Number: RC0402FR-074K7L; Alternate View: Yes; Automotive Qualified: No; Combined Parameters: TCR:100ppm/K;Tol.:-1%/+1%;Vdc:50V;Idc:-;P:63mW; Package Imperial: 0402; Package Metric: 1005; QuickPick: 4.7k / 1% / 0.0630 / 0402; Style: Single; Symbol Type: Resistor; Single; Standard; 2 Pins; Version Number: V01; Voltage DC (max): 50V; Application: Standard; Article Number: 327541; Component Kind: Standard; ComponentLink1Description: Vendor WebSite; ComponentLink1URL: http://www.yageo.com/; ComponentLink2Description: Datasheet; ComponentLink2URL: http://webnetprod.muc.infineon.com/PCBPortal_Prod/WorkFlows/AltiumComponent/StandardDocumentation?Versionid=327542; Multiple Parts: No; Package Description: Resistor,Chip;1.00mm L X 0.50mm W X 0.40mm H; PackageReference: 0402; Part Type: Resistor; PLMStatus: Active; ROHS Compliant: Y; Second Source allowed: Yes; Supplier 1: Farnell; Supplier 2: DigiKey; Supplier 3: Mouser; Supplier Part Number 1: 9239316; Supplier Part Number 2: 311-4.7KLRCT-ND; Supplier Part Number 3: 603-RC0402FR-074K7L; Technology: Thick Film; Temperature max: 155; Temperature min: -55; Temperature Range: Military; Tolerance Neg: 1%; Tolerance Pos: 1%; Type: Standard; Value: 4.7k; Library Name: AURIX_Lite_Kit_V2.0.SCHLIB
R21 = 341777: 2.2 kOhms ±1% 0.063W, 1/16W Chip Resistor 0402 (1005 Metric) Automotive AEC-Q200 Thick Film; Manufacturer: Vishay; Manufacturer Component Status: Active; Manufacturer Order Number: CRCW04022K20FKED; Multiple Parts: No; Alternate View: Yes; Automotive Qualified: AEC Q200; Combined Parameters: TCR:100ppm/K;Tol.:-1%/+1%;Vdc:50V;Idc:-;P:63mW; ComponentStatus: Released; Legacy DeviceID: RES01800379; QuickPick: 2.2k / 1% / 0.0630 / 0402; Style: Single; Symbol Type: Resistor; Single; Standard; 2 Pins; Version Number: V01; Voltage DC (max): 50V; Article Number: 341777; Component Kind: Standard; ComponentLink1Description: Vendor WebSite; ComponentLink1URL: http://www.vishay.com; ComponentLink2Description: Datasheet; ComponentLink2URL: http://webnetprod.muc.infineon.com/PCBPortal_Prod/WorkFlows/AltiumComponent/StandardDocumentation?Versionid=341778; Legacy PartNumber: RES01800379; PackageReference: 0402; Part Type: Resistor; PLMStatus: Active; ROHS Compliant: Y; Second Source allowed: Yes; Supplier 1: Farnell; Supplier 2: DigiKey; Supplier 3: Mouser; Supplier Part Number 2: 541-2.20KLCT-ND; Technology: Thick Film; Temperature max: 155; Temperature min: -55; Temperature Range: Military; Tolerance Neg: 1%; Tolerance Pos: 1%; Type: Standard; Value: 2.2k; Library Name: AURIX_Lite_Kit_V2.0.SCHLIB
TP1 TP2 = 377411: Black bead terminal assembly 1.02mm hole; Legacy PartNumber: CON01500046; Manufacturer: Vero Technologies; Manufacturer Order Number: 20-2137; Alternate View: Yes; Automotive Qualified: No; Combined Parameters: Board-to-Cable None (onboard);1x1;Mounting:THT;Orient:None (onboard);Shielded:No; Legacy DeviceID: VER_TESTPOINT_PTH; PLMStatus: Active; QuickPick: None (onboard) / Board-to-Cable; Style: Looped Terminal; Symbol Type: Connector, 1 Lead, Female; Value: 20-2137; Version Number: V01; Voltage DC (max): na; Application: Test; Article Number: 377411; Component Kind: Standard; ComponentLink1Description: Vendor WebSite; ComponentLink1URL: http://www.verotl.com; ComponentLink2Description: Datasheet; ComponentLink2URL: http://webnetprod.muc.infineon.com/PCBPortal_Prod/WorkFlows/AltiumComponent/StandardDocumentation?Versionid=377412; Multiple Parts: No; Package Description: Test Point, 1.00mm lead width,round,1.2mm Dia X 4.60mm H body; Part Type: Connector; ROHS Compliant: N; Second Source allowed: Yes; Supplier 1: Farnell; Supplier 2: DigiKey; Supplier 3: Mouser; Supplier Part Number 1: 8731128; Temperature Range: Military; Type: Board-to-Cable; Library Name: AURIX_Lite_Kit_V2.0.SCHLIB
U1 = 408890: Buffer, Non-Inverting 2 Element 4 Bit per Element 3-State Output 20-TSSOP; Legacy PartNumber: IC02600552; Manufacturer: Texas Instruments; Manufacturer Component Status: Active; Automotive Qualified: No; ComponentStatus: Released; Legacy DeviceID: TI_SN74AHC244PWR; Second Source allowed: Yes; Symbol Type: Octal Buffers/Drivers With 3-State Outputs; Version Number: V01; Voltage DC (max): na; Article Number: 408890; Component Kind: Standard; ComponentLink1Description: Vendor WebSite; ComponentLink1URL: http://www.ti.com; ComponentLink2Description: Datasheet; ComponentLink2URL: http://webnetprod.muc.infineon.com/PCBPortal_Prod/WorkFlows/AltiumComponent/StandardDocumentation?Versionid=408891; Manufacturer Order Number: SN74AHC244PWR; PackageReference: TSSOP-20 (PW); Part Type: Logic Device; PLMStatus: Active; Supplier 1: Farnell; Supplier 2: DigiKey; Supplier 3: Mouser; Supplier Part Number 1: 2436833; Supplier Part Number 2: 296-1097-1-ND; Supplier Part Number 3: 595-SN74AHC244PWR; Temperature max: 0; Temperature min: 0; Temperature Range: Military; Value: SN74AHC244PWR; Library Name: AURIX_Lite_Kit_V2.0.SCHLIB
U2 = TPD4S012DRYR: Clamp 3A (8/20µs) Ipp Tvs Diode Surface Mount 6-SON (1.45x1); Manufacturer: Texas Instruments; Geometry.Height: 0.6mm; Arrow Part Number: TPD4S012DRYR; Arrow Price/Stock: https://www.arrow.com/en/products/tpd4s012dryr/texas-instruments?region=nac; Component Kind: Standard; Datasheet Link: https://www.ti.com/lit/gpn/TPD4S012; Library Name: TPD4S012DRYR.SchLib; Manufacturer Order Number: TPD4S012DRYR; Manufacturer_Name: Texas Instruments; Manufacturer_Part_Number: TPD4S012DRYR; Mouser Part Number: 595-TPD4S012DRYR; Mouser Price/Stock: https://www.mouser.co.uk/ProductDetail/Texas-Instruments/TPD4S012DRYR?qs=XGzIaZb%2FFYJve%2FbrCpoVgg%3D%3D; Supplier Part Number 2: 296-24244-1-ND
U3 = 408900: Buffer, Non-Inverting 1 Element 1 Bit per Element 3-State Output SOT-23-5; Legacy PartNumber: IC02600553; Manufacturer: Texas Instruments; Manufacturer Component Status: Active; Automotive Qualified: No; ComponentStatus: Released; Legacy DeviceID: TI_SN74LVC1G125DBVR; Second Source allowed: Yes; Symbol Type: Single Bus Buffer Gate With 3-State Output; Version Number: V01; Voltage DC (max): na; Article Number: 408900; Component Kind: Standard; ComponentLink1Description: Vendor WebSite; ComponentLink1URL: http://www.ti.com; ComponentLink2Description: Datasheet; ComponentLink2URL: http://webnetprod.muc.infineon.com/PCBPortal_Prod/WorkFlows/AltiumComponent/StandardDocumentation?Versionid=408901; Manufacturer Order Number: SN74LVC1G125DBVR; PackageReference: SOT23-5 (DBV); Part Type: Logic Device; PLMStatus: Active; Supplier 1: Farnell; Supplier 2: DigiKey; Supplier 3: Mouser; Supplier Part Number 1: 2437138; Supplier Part Number 2: 296-11603-1-ND; Supplier Part Number 3: 595-SN74LVC1G125DBVR; Temperature max: 0; Temperature min: 0; Temperature Range: Military; Value: SN74LVC1G125DBVR; Library Name: AURIX_Lite_Kit_V2.0.SCHLIB
U4 U5 = 409515: Voltage Level Translator Bidirectional 1 Circuit 1 Channel 420Mbps SOT-23-6; Legacy PartNumber: IC02600608; Manufacturer: Texas Instruments; Manufacturer Component Status: Active; Automotive Qualified: No; Legacy DeviceID: TI_SN74LVC1T45DBV; Second Source allowed: Yes; Symbol Type: Single-Bit Dual-Supply Bus Transceiver with Configurable Voltage Translation and 3-State Outputs; Version Number: V01; Voltage DC (max): na; Article Number: 409515; Component Kind: Standard; ComponentLink1Description: Vendor WebSite; ComponentLink1URL: http://www.ti.com; ComponentLink2Description: Datasheet; ComponentLink2URL: http://webnetprod.muc.infineon.com/PCBPortal_Prod/WorkFlows/AltiumComponent/StandardDocumentation?Versionid=409516; Manufacturer Order Number: SN74LVC1T45DBVR; PackageReference: SOT23-6; Part Type: Logic Device; PLMStatus: Active; Supplier 1: Farnell; Supplier 2: DigiKey; Supplier 3: Mouser; Supplier Part Number 1: 2437195; Supplier Part Number 2: 296-16843-1-ND; Supplier Part Number 3: 595-SN74LVC1T45DBVR; Temperature Range: Military; Value: SN74LVC1T45DBVR; Library Name: AURIX_Lite_Kit_V2.0.SCHLIB
U6 = 409630: EEPROM Memory IC 1Kbit Microwire 2 MHz 8-SOIC; Legacy PartNumber: IC02600617; Manufacturer: Microchip Technology; Manufacturer Component Status: Active; Manufacturer Order Number: 93LC46BT-I/SN; Automotive Qualified: No; Legacy DeviceID: MT_93LC46B-I/SN; Second Source allowed: Yes; Symbol Type: 1K Microwire Compatible Serial EEPROM; Version Number: V01; Voltage DC (max): na; Article Number: 409630; Component Kind: Standard; ComponentLink1Description: Vendor WebSite; ComponentLink1URL: http://www.microchip.com/; ComponentLink2Description: Datasheet; ComponentLink2URL: http://webnetprod.muc.infineon.com/PCBPortal_Prod/WorkFlows/AltiumComponent/StandardDocumentation?Versionid=409631; PackageReference: SOIC-8; Part Type: Memory; PLMStatus: Active; Supplier 1: Farnell; Supplier 2: DigiKey; Supplier 3: Mouser; Supplier Part Number 1: 9758232; Supplier Part Number 2: 93LC46BT-I/SNCT-ND; Supplier Part Number 3: 579-93LC46BISN; Temperature Range: Military; Value: 93LC46B-I/SN; Library Name: AURIX_Lite_Kit_V2.0.SCHLIB
Y1 = 409657: 12 MHz ±15ppm Crystal 8pF 120 Ohms 4-SMD, No Lead; Legacy PartNumber: IC02600619; Manufacturer: Nihon Dempa Kogyo; Manufacturer Component Status: Active; Manufacturer Order Number: NX3225SA-12.000M-STD-CRS-2; Automotive Qualified: AEC Q200; Combined Parameters: Standard Standard Crystal;Tol.:-50%/+50%; ComponentStatus: Released; Legacy DeviceID: NDK_NX3225SA-12.000M-STD-CRS-2; QuickPick: 12000000 / Crystal; Second Source allowed: Yes; Style: Standard; Symbol type: Standard Crystal Symbol, 4 Pins; Tolerance Neg: 50%; Version Number: V01; Voltage DC (max): na; Application: Standard; Article Number: 409657; Component Kind: Standard; ComponentLink1Description: Vendor WebSite; ComponentLink1URL: http://www.ndk.com/en/; ComponentLink2Description: Datasheet; ComponentLink2URL: http://webnetprod.muc.infineon.com/PCBPortal_Prod/WorkFlows/AltiumComponent/StandardDocumentation?Versionid=409658; Package Description: Crystal; 3.2mm W X 2.5mm L X 0.6mm H body; PackageReference: SMD 3.2 x 2.5 x 0.6mm; Part Type: Clock; PLMStatus: Active; ROHS Compliant: Y; Supplier 1: Farnell; Supplier 2: DigiKey; Supplier 3: Mouser; Supplier Part Number 2: 644-1128-1-ND; Temperature max: 125; Temperature min: -40; Temperature Range: Automotive; Tolerance Pos: 50%; Type: Crystal; Value: 12MHz; Library Name: AURIX_Lite_Kit_V2.0.SCHLIB
JMP1 = USB_HOLD: Component Kind: Standard; Library Name: USB_HOLD.SchLib
